# Altium schematic sheet Banana2USB.SchDoc(Banana2USB)
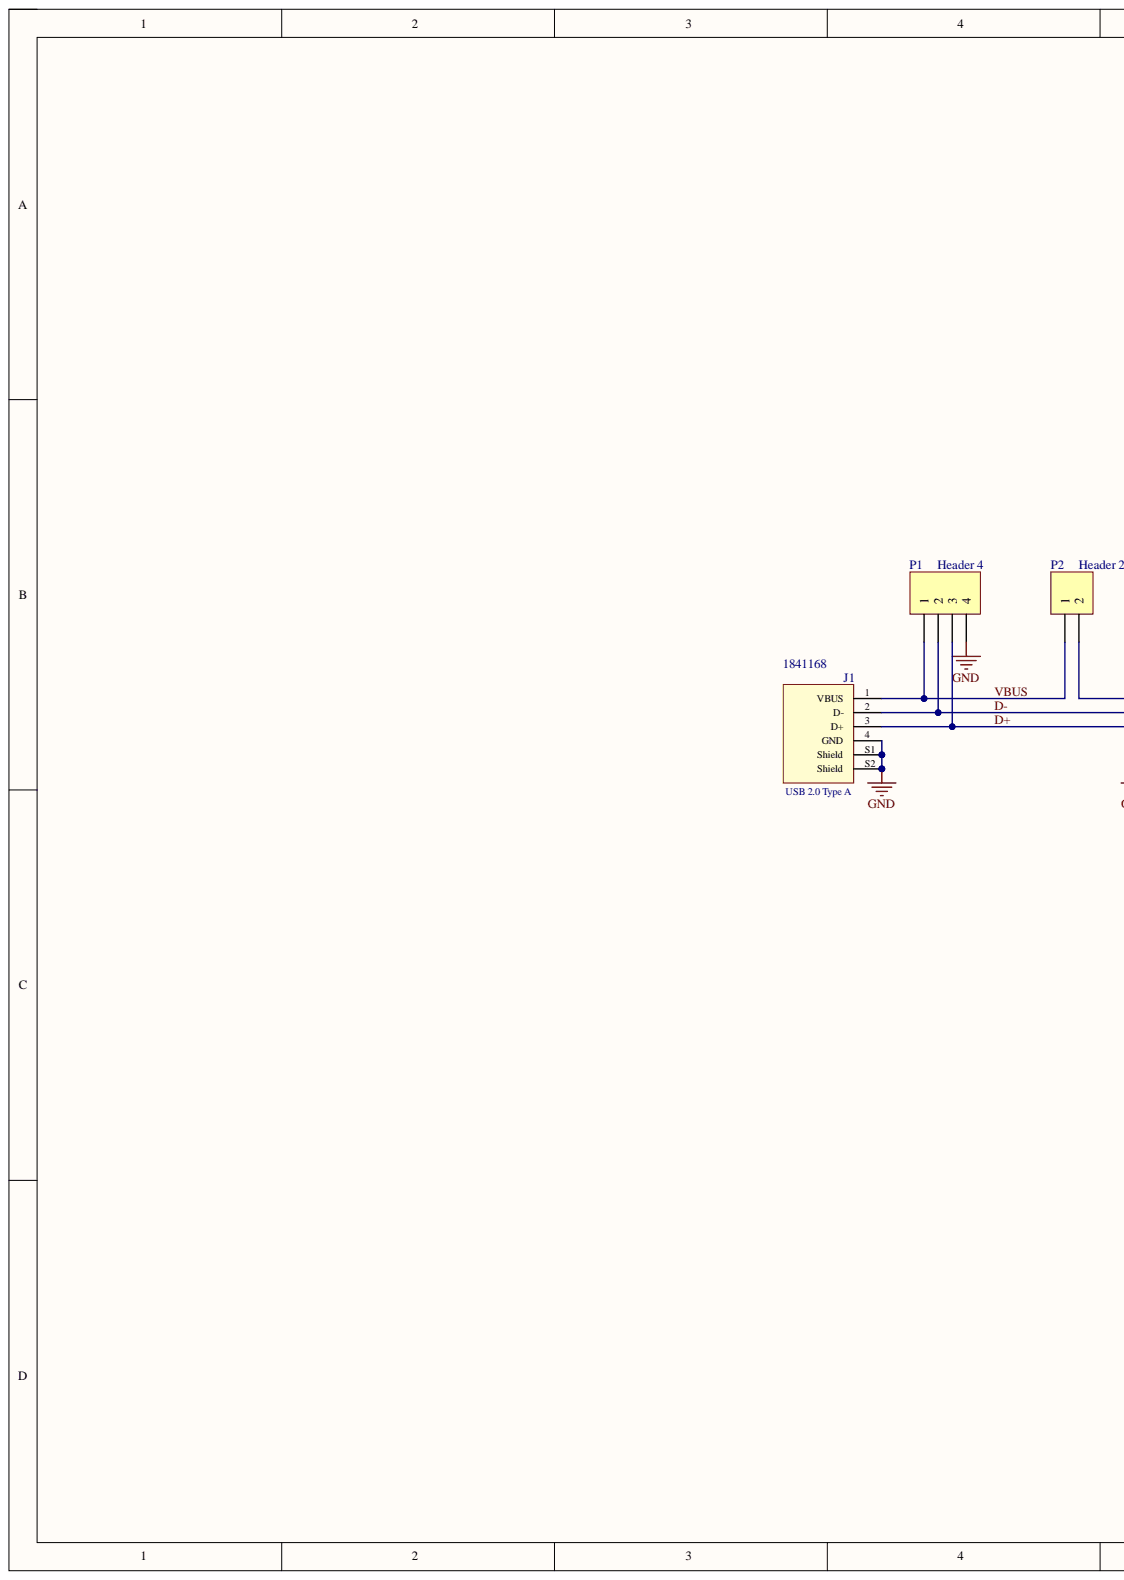
[diagram p0: Banana2USB.SchDoc, left]
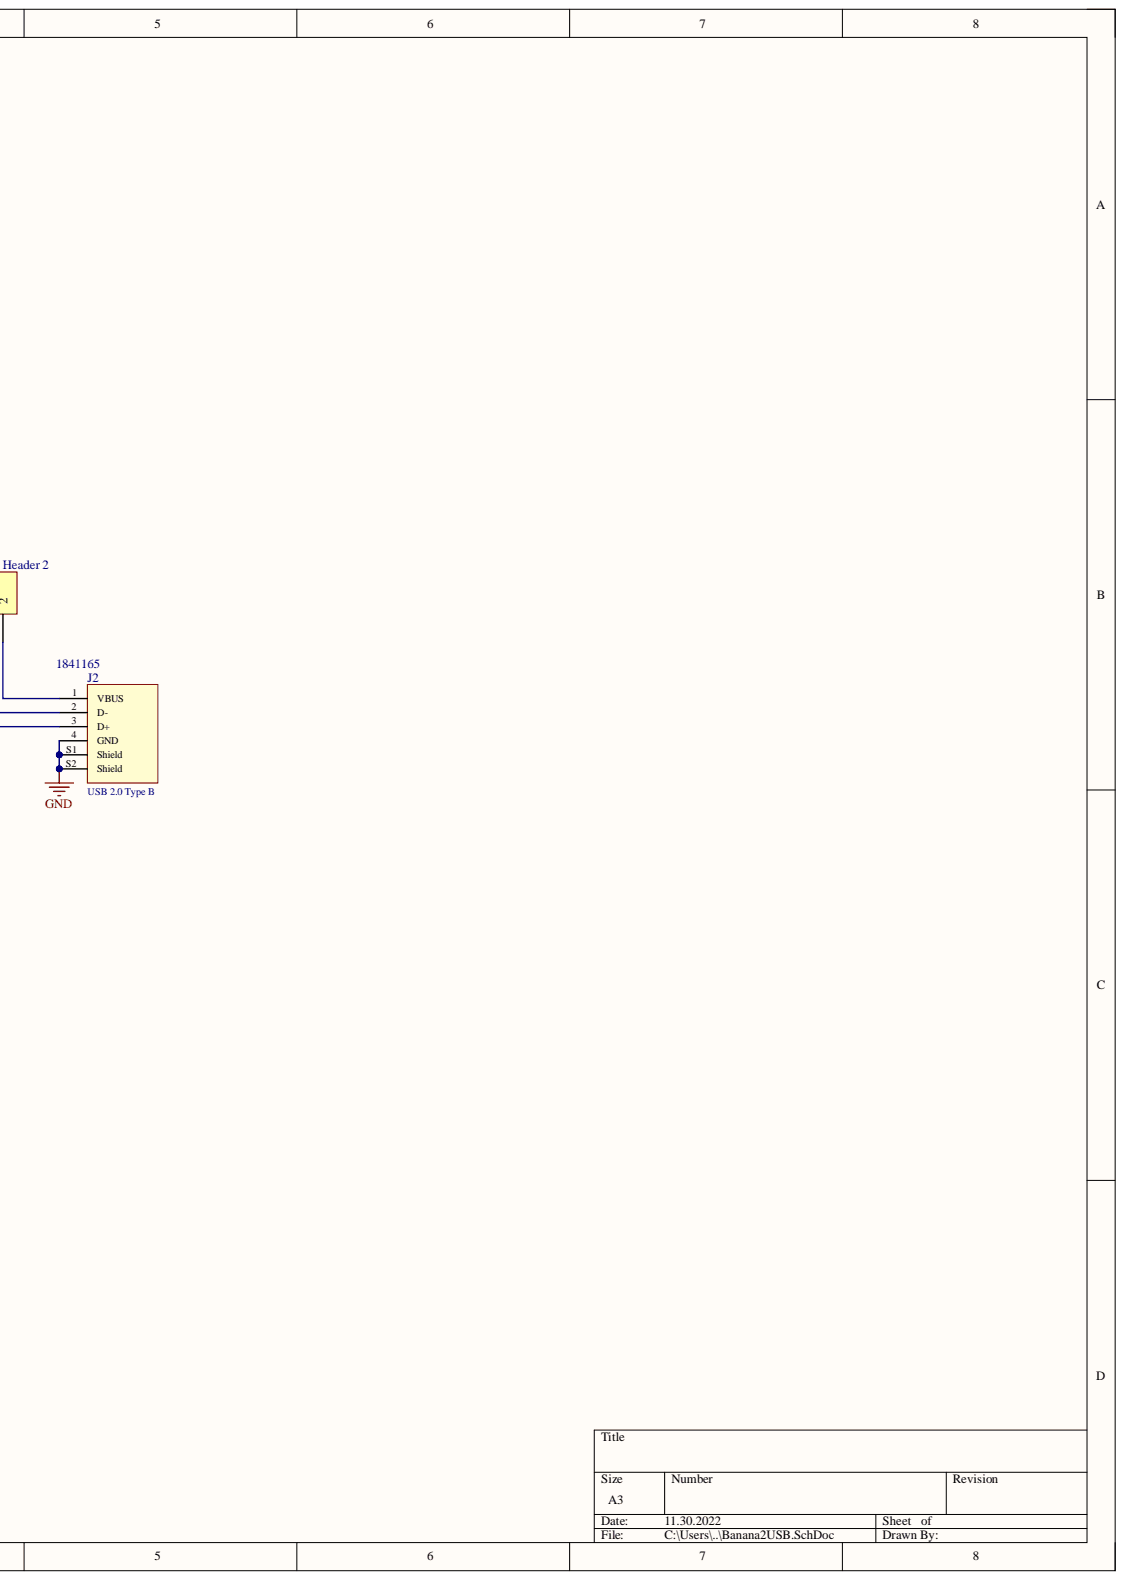
[diagram p1: Banana2USB.SchDoc, right]

## Parts
J1  USB 2.0 Type A  Supplier Part Number 1: 1841168  [614004135023]
J2  USB 2.0 Type B  Supplier Part Number 1: 1841165  [61400413321]
P1  Header 4  [HDR1X4]
P2  Header 2  [HDR1X2]

## Nets
GND: J1.4(GND) J1.S1(Shield) J1.S2(Shield) J2.4(GND) J2.S1(Shield) J2.S2(Shield) P1.4
D+: J1.3(D+) J2.3(D+) P1.3
D-: J1.2(D-) J2.2(D-) P1.2
VBUS: J1.1(VBUS) P1.1 P2.1
NetJ2_1: J2.1(VBUS) P2.2

## Library data of the parts
J1 = 614004135023: USB 2.0 Type A Vertical THT WR-COM; Gender: Female; Rated Current: 1.5 A; Component Kind: Standard; ComponentLink1Description: Manufacturer; ComponentLink1URL: http://www.we-online.de; ComponentLink2Description: Datasheet; ComponentLink2URL: http://katalog.we-online.de/em/datasheet/614004135023.pdf; Interface Type: USB 2.0 Type A; Library Name: ALTIUM-WR-COM-rev18a.IntLib; Mounting Technology: THT; Operating Temperature: -40 to 105 degC; Orientation: Vertical; Packaging: Tray; Part Number: 614004135023; Published Date: May 2018; Supplier 1: Farnell; Publisher: Wurth Elektronik
J2 = 61400413321: USB 2.0 Type B Vertical THT WR-COM; Gender: Female; Rated Current: 1.5 A; Component Kind: Standard; ComponentLink1Description: Manufacturer; ComponentLink1URL: http://www.we-online.de; ComponentLink2Description: Datasheet; ComponentLink2URL: http://katalog.we-online.de/em/datasheet/61400413321.pdf; Interface Type: USB 2.0 Type B; Library Name: ALTIUM-WR-COM-rev18a.IntLib; Mounting Technology: THT; Operating Temperature: -40 to 105 degC; Orientation: Vertical; Packaging: Tray; Part Number: 61400413321; Published Date: May 2018; Supplier 1: Farnell; Publisher: Wurth Elektronik
P1 = Header 4: Header, 4-Pin; Component Kind: Standard; LatestRevisionDate: 17-Jul-2002; LatestRevisionNote: Re-released for DXP Platform.; Library Name: Miscellaneous Connectors.IntLib; Signal Integrity: Connector; Publisher: Altium Limited
P2 = Header 2: Header, 2-Pin; Component Kind: Standard; LatestRevisionDate: 17-Jul-2002; LatestRevisionNote: Re-released for DXP Platform.; Library Name: Miscellaneous Connectors.IntLib; Signal Integrity: Connector; Publisher: Altium Limited
